# Altium schematic sheet CAN Transciever.SchDoc
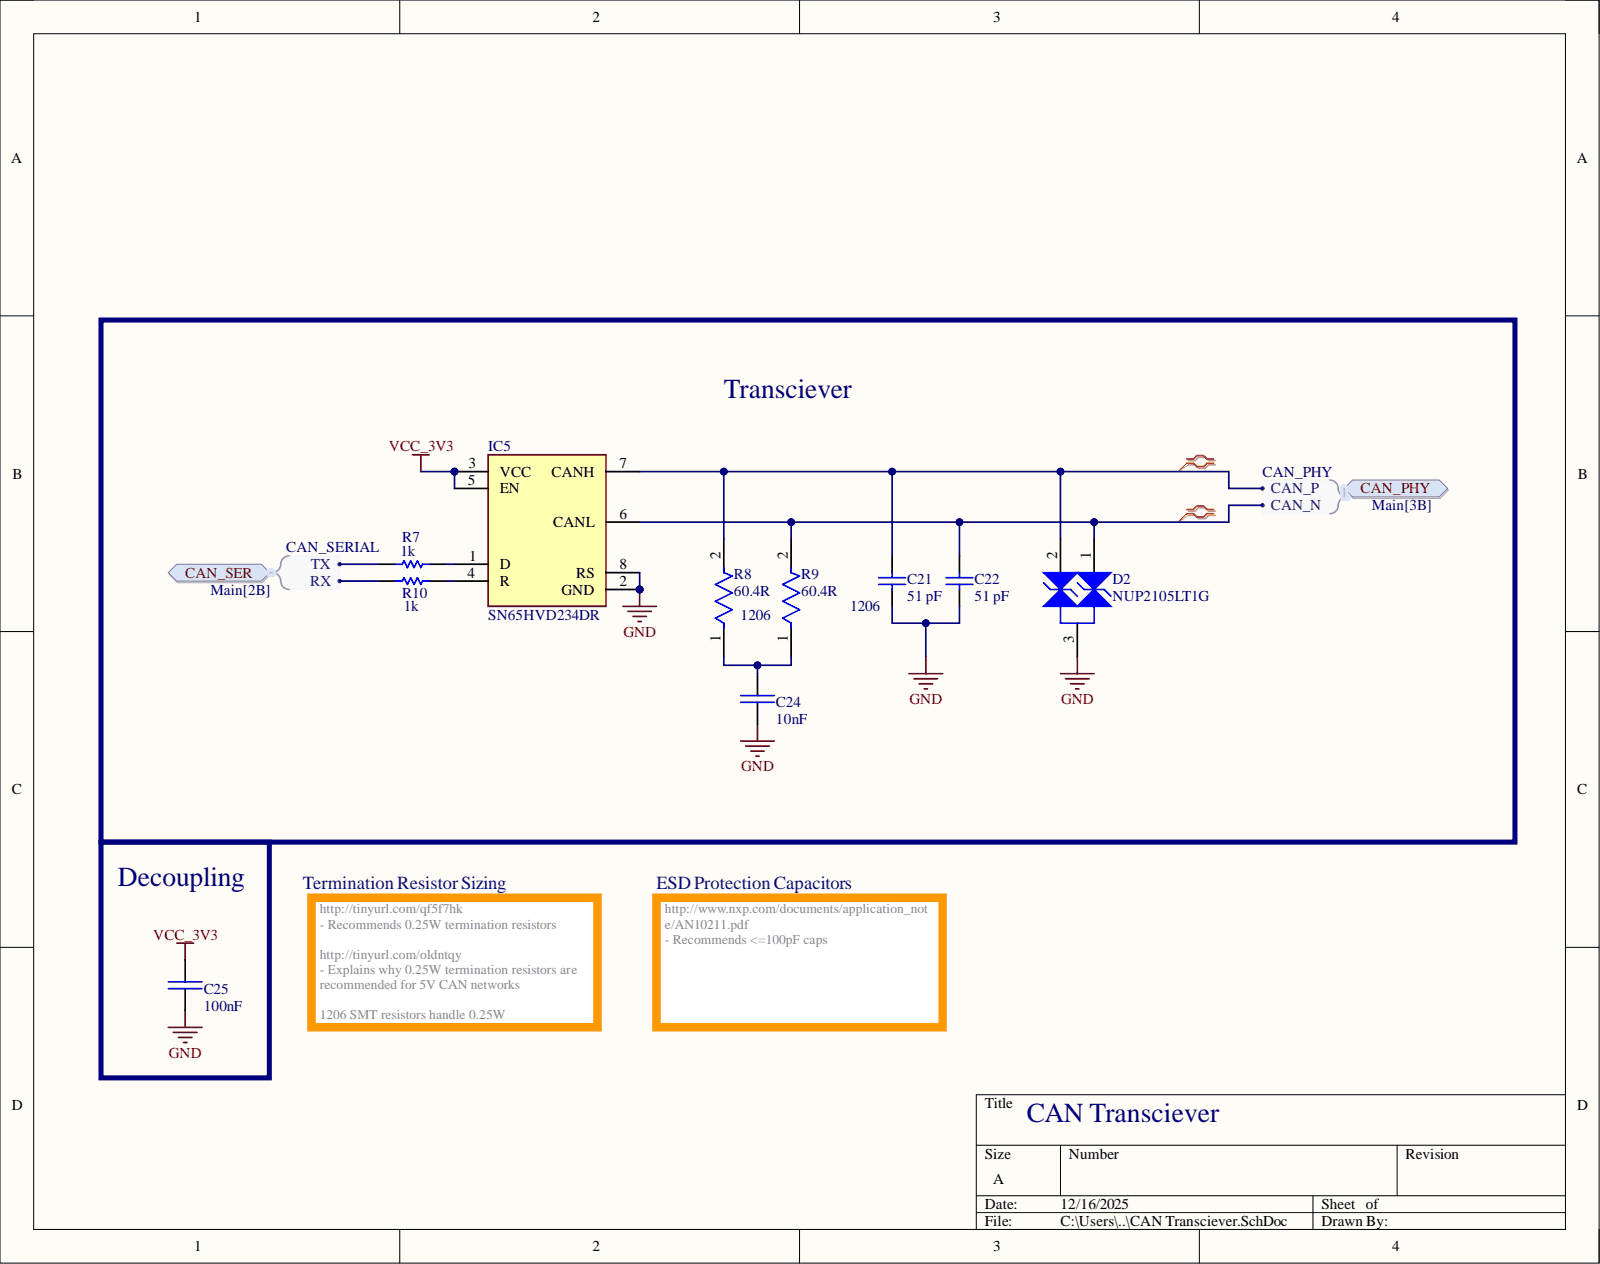
[diagram p0: CAN Transciever.SchDoc]

## Parts
C21  51 pF  [CAPC1608X90X35ML15T15]
C22  51 pF  [CAPC1608X90X35ML15T15]
C24  10nF  [CAP_SM0603_YAGEOCC2_N]
C25  100nF  [CAP_SM0603_YAGEOCC2_N]
D2  NUP2105LT1G  [ONSC-SOT-23-3-318-08_V]
IC5  SN65HVD234DR  [SOIC127P600X175-8N]
R7  1k  [RESC1609X50X30NL10T20]
R8  60.4R  [FP-AC1206-MFG]
R9  60.4R  [FP-AC1206-MFG]
R10  1k  [RESC1609X50X30NL10T20]

## Ports
CAN_PHY, CAN_PHY.CAN_N, CAN_PHY.CAN_P, CAN_SER, CAN_SER.RX, CAN_SER.TX

## Nets
GND: C21.1 C22.1 C24.2 C25.2 D2.3 IC5.2(GND) IC5.8(RS)
VCC_3V3: C25.1 IC5.3(VCC) IC5.5(EN)
NetC21_2: C21.2 D2.2 IC5.7(CANH) R8.2 ; port CAN_PHY
NetC22_2: C22.2 D2.1 IC5.6(CANL) R9.2 ; port CAN_PHY
NetC24_1: C24.1 R8.1 R9.1
NetIC5_1: IC5.1(D) R7.2
NetIC5_4: IC5.4(R) R10.2
NetR7_1: R7.1 ; port CAN_SER
NetR10_1: R10.1 ; port CAN_SER

## Library data of the parts
C21 C22 = CMP-2006-04333-1: Manufacturer: AVX Interconnect / Elco; Part Number: 06035A510JAT2A; Component Kind: Standard; Library Name: Altium Content Vault
C24 = CC0603KRX7R9BB103: CAP 10nF SM0603 X7R 50V YAGEO CC2; Tolerance (%): 10; Voltage Rating (Volts): 50; Category: Capacitor; Component Kind: Standard; ComponentLink1Description: Manufacturer Website; ComponentLink1URL: http://www.yageo.com; Library Name: ResistorCapsInductors.IntLib; Manufacturer: Yageo; Manufacturer Part Number: CC0603KRX7R9BB103; Package: 0603; Supplier 1: Farnell; Supplier 2: Digi-Key; Supplier Part Number 1: 722236; Supplier Part Number 2: 311-1085-1-ND; Value: 10nF
C25 = CC0603KRX7R9BB104: CAP 100nF SM0603 X7R 50V YAGEO CC2; Tolerance (%): 10; Voltage Rating (Volts): 50; Category: Capacitor; Component Kind: Standard; ComponentLink1Description: Manufacturer Website; ComponentLink1URL: http://www.yageo.com; Library Name: ResistorCapsInductors.IntLib; Manufacturer: Yageo; Manufacturer Part Number: CC0603KRX7R9BB104; Package: 0603; Supplier 1: Farnell; Supplier 2: Digi-Key; Supplier Part Number 1: 1362556; Supplier Part Number 2: 311-1344-1-ND; Value: 100nF
D2 = NUP2105LT1G: Dual Line CAN Bus Protector, 3-Pin SOT-23, Pb-Free, Tape and Reel; Manufacturer: On Semiconductor; C Max (pF): 30; Direction: Bidirectional; IR Max (uA): 0.1; Number of Lines: 2; PPK Max (W): 350; V(BR) Min (V): 26.2; Component Kind: Standard; ComponentLink1Description: Manufacturer URL; ComponentLink1URL: http://www.onsemi.com/; ComponentLink2Description: Datasheet; ComponentLink2URL: http://www.onsemi.cn/pub_link/Collateral/NUP2105L-D.PDF; ComponentLink3Description: Package Specification; ComponentLink3URL: http://www.onsemi.cn/pub_link/Collateral/318-08.PDF; DatasheetVersion: Rev. 5; Library Name: DiodesAndFuses.IntLib; Manufacturer Part Number: NUP2105LT1G; Mounting Technology: Surface Mount; PackageDescription: 3-Pin Small Outline Transistor, Body 2.92 x 1.3 mm, Pitch 0.95 mm; PackageReference: SOT-23-3-318-08; PackageVersion: Rev. AP, 11/2009; Packing: Tape and Reel; PartNumber: NUP2105LT1G; RoHS: Pb-Free; Supplier 1: DigiKey; Supplier Part Number 1: NUP2105LT1GOSDKR-ND
IC5 = SN65HVD234D: NEW CAN IC; Manufacturer_Name: Texas Instruments; Arrow Part Number: SN65HVD234D; Arrow Price/Stock: https://www.arrow.com/en/products/sn65hvd234d/texas-instruments?region=nac; Component Kind: Standard; Datasheet Link: http://www.ti.com/lit/gpn/sn65hvd234; Height: 1.75mm; Library Name: Misc.IntLib; Manufacturer_Part_Number: SN65HVD234D; Mouser Part Number: 595-SN65HVD234D; Mouser Price/Stock: https://www.mouser.co.uk/ProductDetail/Texas-Instruments/SN65HVD234D?qs=QViXGNcIEAuKNNuTonYqjw%3D%3D; Supplier 1: DigiKey; Supplier Part Number 1: 296-27991-1-ND
R7 R10 = CMP-1012-00510-2: Manufacturer: Vishay Dale; Part Number: CRCW06031K00FKEB; Component Kind: Standard; Library Name: Altium Content Vault
R8 R9 = AC1206FR-0760R4L: RES SMD 60.4 OHM 1% 1/4W 1206 CAN Termination; Manufacturer: Yageo; Automotive Grade: Grade 0; Power Rating: 0.25W; Resistance: 60.4Ω; Tolerance: 0.01; Voltage Rating: 200V; Automotive: Yes; Category: Res; Component Kind: Standard; ComponentLink2Description: Datasheet; ComponentLink2URL: http://www.yageo.com/NewPortal/yageodocoutput?fileName=/pdf/R-Chip/PYu-AC_51_RoHS_L_6.pdf; Composition: Thick Film; Device Class L1: Passive Components; Device Class L2: Resistors; Device Class L3: Chip SMD Resistors; Digikey Description: RES SMD 60.4 OHM 1% 1/4W 1206; Footprint Url: http://www.yageo.com/exep/pages/download/literatures/PYu-R_Mount_10.pdf; Height: 0.65mm; Ipc Land Pattern Name: RESC310160X55; Lead Free: Yes; Library Name: ResistorCapsInductors.IntLib; Manufacturer Part Number: AC1206FR-0760R4L; Mouser Description: Thick Film Resistors 60.4ohm 1% 1/4W AEC-Q200; Octopart: https://octopart.com/ac1206fr-0760r4l-yageo-21711120; Package: AC1206; RoHS: TRUE; Series: AC; Temperature: -55°C to +155°C; Temperature Range High: +155°C; Temperature Range Low: -55°C; Value: 60.4R
